# Altium schematic sheet 09_ETH2.SchDoc
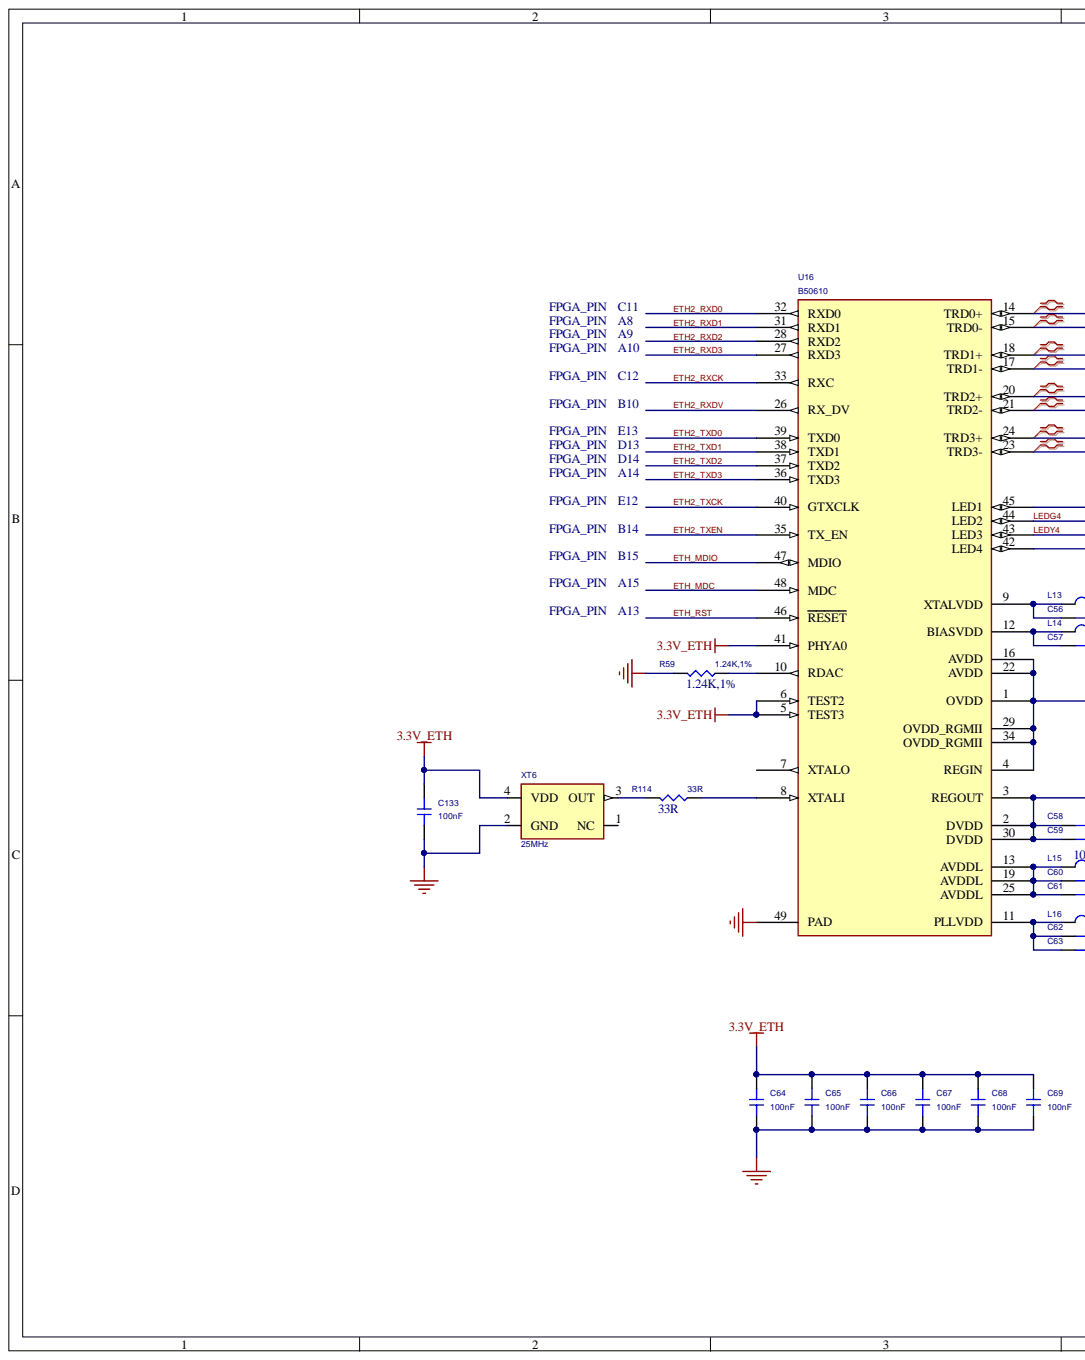
[diagram p0: 09_ETH2.SchDoc, left]
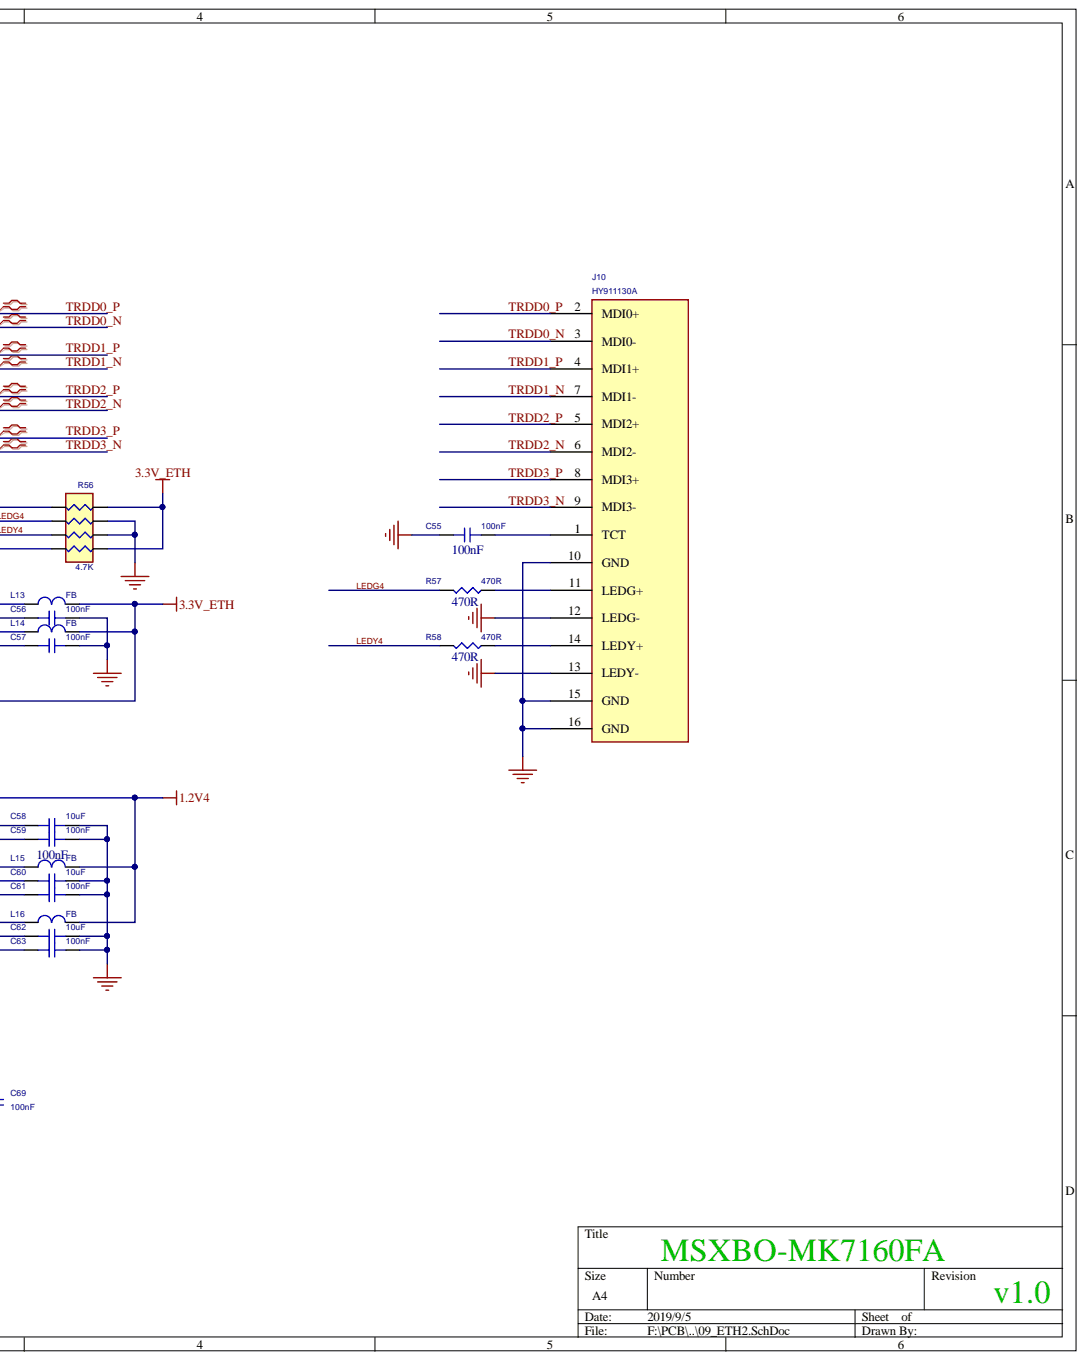
[diagram p1: 09_ETH2.SchDoc, right]

## Parts
C55  100nF  [CAP0402]
C56  100nF  [CAP0402]
C57  100nF  [CAP0402]
C58  10uF  [C0603]
C59  100nF  [CAP0402]
C60  10uF  [C0603]
C61  100nF  [CAP0402]
C62  10uF  [C0603]
C63  100nF  [CAP0402]
C64  100nF  [CAP0402]
C65  100nF  [CAP0402]
C66  100nF  [CAP0402]
C67  100nF  [CAP0402]
C68  100nF  [CAP0402]
C69  100nF  [CAP0402]
C133  100nF  [CAP0402]
J10  HY911130A  [HY911130A]
L13  FB  [L0603]
L14  FB  [L0603]
L15  FB  [L0603]
L16  FB  [L0603]
R56  4.7K  [RA4_0603]
R57  470R  [R0603]
R58  470R  [R0603]
R59  1.24K,1%  [R0603]
R114  33R  [R0603]
U16  B50610  [QFN48_50P7X7X1_E4]
XT6  25MHz  [CRY3225_4]

## Nets
1.2V4: C58.1 C59.1 L15.2 L16.2 U16.2(DVDD) U16.3(REGOUT) U16.30(DVDD)
3.3V_ETH: C64.2 C65.2 C66.2 C67.2 C68.2 C69.2 C133.2 L13.2 L14.2 R56.1 R56.4 U16.1(OVDD) U16.4(REGIN) U16.5(TEST3) U16.6(TEST2) U16.16(AVDD) U16.22(AVDD) U16.29(OVDD_RGMII) U16.34(OVDD_RGMII) U16.41(PHYA0) XT6.4(VDD)
GND: C55.1 C56.2 C57.2 C58.2 C59.2 C60.2 C61.2 C62.2 C63.2 C64.1 C65.1 C66.1 C67.1 C68.1 C69.1 C133.1 J10.10(GND) J10.12(LEDG-) J10.13(LEDY-) J10.15(GND) J10.16(GND) R56.2 R56.3 R59.1 U16.49(PAD) XT6.2(GND)
ETH2_RXCK: U16.33(RXC)
ETH2_RXD0: U16.32(RXD0)
ETH2_RXD1: U16.31(RXD1)
ETH2_RXD2: U16.28(RXD2)
ETH2_RXD3: U16.27(RXD3)
ETH2_RXDV: U16.26(RX_DV)
ETH2_TXCK: U16.40(GTXCLK)
ETH2_TXD0: U16.39(TXD0)
ETH2_TXD1: U16.38(TXD1)
ETH2_TXD2: U16.37(TXD2)
ETH2_TXD3: U16.36(TXD3)
ETH2_TXEN: U16.35(TX_EN)
ETH_MDC: U16.48(MDC)
ETH_MDIO: U16.47(MDIO)
ETH_RST: U16.46(RESET)
LEDG4: R56.7 R57.1 U16.44(LED2)
LEDY4: R56.6 R58.1 U16.43(LED3)
TRDD0_N: J10.3(MDI0-) U16.15(TRD0-)
TRDD0_P: J10.2(MDI0+) U16.14(TRD0+)
TRDD1_N: J10.7(MDI1-) U16.17(TRD1-)
TRDD1_P: J10.4(MDI1+) U16.18(TRD1+)
TRDD2_N: J10.6(MDI2-) U16.21(TRD2-)
TRDD2_P: J10.5(MDI2+) U16.20(TRD2+)
TRDD3_N: J10.9(MDI3-) U16.23(TRD3-)
TRDD3_P: J10.8(MDI3+) U16.24(TRD3+)
NetC55_2: C55.2 J10.1(TCT)
NetC56_1: C56.1 L13.1 U16.9(XTALVDD)
NetC57_1: C57.1 L14.1 U16.12(BIASVDD)
NetC60_1: C60.1 C61.1 L15.1 U16.13(AVDDL) U16.19(AVDDL) U16.25(AVDDL)
NetC62_1: C62.1 C63.1 L16.1 U16.11(PLLVDD)
NetJ10_11: J10.11(LEDG+) R57.2
NetJ10_14: J10.14(LEDY+) R58.2
NetR56_5: R56.5 U16.42(LED4)
NetR56_8: R56.8 U16.45(LED1)
NetR59_2: R59.2 U16.10(RDAC)
NetR114_1: R114.1 XT6.3(OUT)
NetR114_2: R114.2 U16.8(XTALI)
FPGA_PIN:

Not connected: U16 7(XTALO); XT6 1(NC)

## Library data of the parts
C55-C69 C133 = CAP1: CURRENT: CIMAX; DIST: FLAT; KNEE: CBMAX; MAX_TEMP: CTMAX; PSpiceTemplate: C^@REFDES %1 %2 ?TOLERANCE|C^@REFDES| @VALUE ?IC/IC=@IC/ TC=@TC1,@TC2 ?TOLERANCE|\n.model C^@REFDES CAP C=1 DEV=@TOLERANCE% TC1=@TC1 TC2=@TC2 VC1=@VC1 VC2=@VC2|; SLOPE: CSMAX; TC1: 0; VOLTAGE: CMAX; Component Kind: Standard; Simulation: CAP1; TC2: 0; VC1: 0; VC2: 0
  C55-C57 C59 C61 C63-C69 C133: Value: 100nF
  C58 C60 C62: Value: 10uF
L13-L16 = INDUCTOR1: CURRENT: LMAX; DIELECTRIC: DSMAX; DIST: FLAT; IL1: 0; PSpiceTemplate: L^@REFDES %1 %2 ?TOLERANCE|L^@REFDES| @VALUE ?IC/IC=@IC/ ?TOLERANCE|\n.model l^@REFDES IND L=1 DEV=@TOLERANCE% TC1=@TC1 TC2=@TC2 IL1=@IL1 IL2=@IL2|; Component Kind: Standard; IL2: 0; Simulation: INDUCTOR1; TC1: 0; TC2: 0; Value: FB
R56 = RA-4: Component Kind: Standard
R57-R59 R114 = RES1: DIST: FLAT; MAX_TEMP: RTMAX; POWER: RMAX; PSpiceTemplate: R^@REFDES %1 %2 ?TOLERANCE|R^@REFDES| @VALUE TC=@TC1,@TC2 ?TOLERANCE|\n.model R^@REFDES RES R=1 DEV=@TOLERANCE% TC1=@TC1 TC2=@TC2|; SLOPE: RSMAX; TC1: 0; VOLTAGE: RVMAX; Component Kind: Standard; Simulation: RES1; TC2: 0
  R57 R58: Value: 470R
  R59: Value: 1.24K,1%
  R114: Value: 33R
XT6 = CRYSTAL3: Component Kind: Standard
J10 = HY911130A: Component Kind: Standard
U16 = B50610: Component Kind: Standard
